# Altium schematic sheet Extra5_connectors.SchDoc
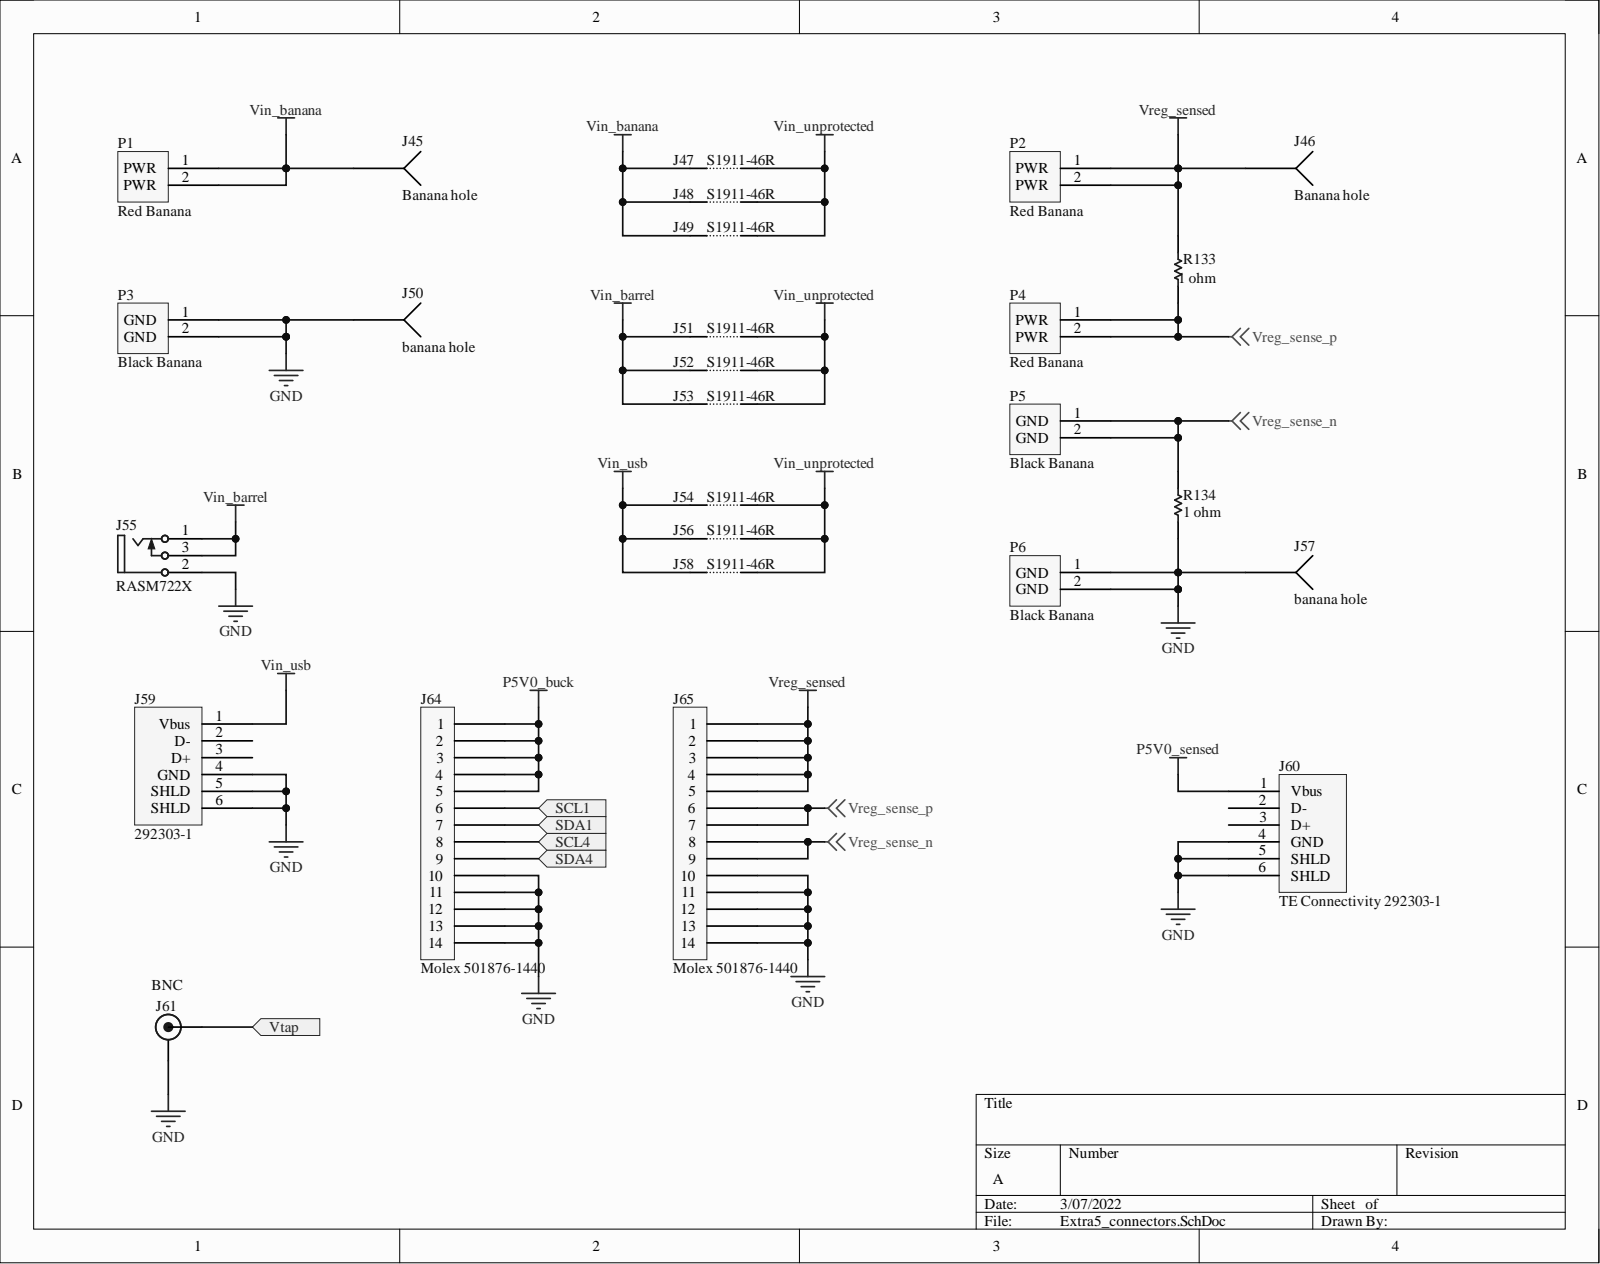
[diagram p0: Extra5_connectors.SchDoc]

## Parts
J45  Banana hole  [PCB-0iteas2z8ibrtv14j3fn-1]
J46  Banana hole  [PCB-0iteas2z8ibrtv14j3fn-1]
J47  S1911-46R  [PCB-ohesuu85b1wonmmrbvbk-1]
J48  S1911-46R  [PCB-ohesuu85b1wonmmrbvbk-1]
J49  S1911-46R  [PCB-ohesuu85b1wonmmrbvbk-1]
J50  banana hole  [PCB-0iteas2z8ibrtv14j3fn-1]
J51  S1911-46R  [PCB-ohesuu85b1wonmmrbvbk-1]
J52  S1911-46R  [PCB-ohesuu85b1wonmmrbvbk-1]
J53  S1911-46R  [PCB-ohesuu85b1wonmmrbvbk-1]
J54  S1911-46R  [PCB-ohesuu85b1wonmmrbvbk-1]
J55  RASM722X  [PCB-3wyqglupejej19j9tyc1-1]
J56  S1911-46R  [PCB-ohesuu85b1wonmmrbvbk-1]
J57  banana hole  [PCB-0iteas2z8ibrtv14j3fn-1]
J58  S1911-46R  [PCB-ohesuu85b1wonmmrbvbk-1]
J59  292303-1  [PCB-13antodwz0m2yw6c6qha-1]
J60  TE Connectivity 292303-1  [PCB-13antodwz0m2yw6c6qha-1]
J61  BNC  [PCB-o9wtl2yy4dlkql9rizqo-1]
J64  Molex 501876-1440  Manufacturer Part Number: 501876-1440  [PCB-1usx3krib1t3yl72r4im-1]
J65  Molex 501876-1440  Manufacturer Part Number: 501876-1440  [PCB-1usx3krib1t3yl72r4im-1]
P1  Red Banana  Color: Red  [PCB-upaa7zt6pz2ck3tbpqlf-1]
P2  Red Banana  Color: Red  [PCB-upaa7zt6pz2ck3tbpqlf-1]
P3  Black Banana  Color: Black  [PCB-adkavfswxprd42xd6ct2-1]
P4  Red Banana  Color: Red  [PCB-upaa7zt6pz2ck3tbpqlf-1]
P5  Black Banana  Color: Black  [PCB-adkavfswxprd42xd6ct2-1]
P6  Black Banana  Color: Black  [PCB-adkavfswxprd42xd6ct2-1]
R133  1 ohm  [PCB-0002-00362-1]
R134  1 ohm  [PCB-0002-00362-1]

## Ports
SCL1, SCL4, SDA1, SDA4, Vtap

## Nets
GND: J50.1 J55.2 J57.1 J59.4(GND) J59.5(SHLD) J59.6(SHLD) J60.4(GND) J60.5(SHLD) J60.6(SHLD) J61.2 J64.10 J64.11 J64.12 J64.13 J64.14 J65.10 J65.11 J65.12 J65.13 J65.14 P3.1 P3.2 P6.1 P6.2 R134.1
P5V0_buck: J64.1 J64.2 J64.3 J64.4 J64.5
P5V0_sensed: J60.1(Vbus)
Vin_banana: J45.1 J47.1 J48.1 J49.1 P1.1 P1.2
Vin_barrel: J51.1 J52.1 J53.1 J55.1 J55.3
Vin_unprotected: J47.2 J48.2 J49.2 J51.2 J52.2 J53.2 J54.2 J56.2 J58.2
Vin_usb: J54.1 J56.1 J58.1 J59.1(Vbus)
Vreg_sense_n: J65.8 J65.9 P5.1 P5.2 R134.2
Vreg_sense_p: J65.6 J65.7 P4.1 P4.2 R133.1
Vreg_sensed: J46.1 J65.1 J65.2 J65.3 J65.4 J65.5 P2.1 P2.2 R133.2
SCL1: J64.6 ; port SCL1
SCL4: J64.8 ; port SCL4
SDA1: J64.7 ; port SDA1
SDA4: J64.9 ; port SDA4
Vtap: J61.1 ; port Vtap

Not connected: J59 2(D-) 3(D+); J60 2(D-) 3(D+)

## Library data of the parts
J45 J46 J50 J57 = CMP-a2bb6bb1a39b7d7c-1: BONDHUS 15816 Hex L-Wrench - Short 1/2In. More details: 1/2In Hex L-wrench - Short, Standard Tool (Accessory Needed f...; Manufacturer Part Number: 1581-6; Current Rating: 15.0 A; Gender: Male; Lead-Free Status: Lead Free; Number of Positions: 1; Voltage Rating (DC): 2.50 kV; Color: Blue; Component Kind: Standard; Contact Material: Brass; Contact Plating: Tin; Insulation Material: Polycarbonate; Library Name: My Personal Space; Mounting Style: Panel; Octopart URL: http://octopart.com/1581-6-pomona+electronics-9286; OctopartID: a2bb6bb1a39b7d7c; Packaging: Bulk; RoHS: Compliant; Size-Diameter: 4.22 mm; Size-Length: 31.0 mm
J47-J49 J51-J54 J56 J58 = CMP-1660de3de5e9c9a7-6: Conn Jumper 2 POS 5.38mm Solder ST SMD T/R; Number of Contacts: 2; Component Kind: Standard; Library Name: My Personal Space; Manufacturer Part Number: S1911-46R; Octopart URL: https://octopart.com/s1911-46r-harwin-22533871; OctopartID: 1660de3de5e9c9a7; Packaging: Cut Tape (CT); REACH SVHC Compliance: No SVHC; RoHS: Compliant
J55 = CMP-330658-7: Connector Power PL 2 POS Solder RA SMD 3 Terminal 1 Port Bulk; Current Rating: 5.00 A; Gender: Female; Lead-Free Status: Lead Free; Number of Contacts: 2; Voltage Rating (AC): 250 V; Color: Black; Component Kind: Standard; Library Name: My Personal Space; Manufacturer Part Number: RASM722X; Mounting Style: Surface Mount, Solder, Through Hole, PCB; Octopart URL: https://octopart.com/rasm722x-switchcraft-142066; OctopartID: 976c5e6498f848ab; REACH SVHC Compliance: No SVHC; RoHS: Compliant
J59 J60 = CMP-777690-15: Conn USB 2.0 Type A RCP 4 POS 2mm/2.5mm Solder RA Thru-Hole 4 Terminal 1 Port Box/Tube; Contact Resistance: 10.0 mΩ (max); Current Rating: 1.00 A; ELV Compliant: Compliant; Gender: Female; Insulation Resistance: 1.00 GΩ (min); Lead-Free Status: Lead Free; Number of Contacts: 4; Number of Mating Cycles: 1500; Voltage Rating (AC): 30.0 V; Component Kind: Standard; Contact Material: Copper Alloy; Contact Plating: Gold Flash over Nickel, Gold Flash, Gold, Gold over Nickel; Flammability Rating: UL 94 V-0; Housing Color: Black; Housing Material: Thermoplastic; Library Name: My Personal Space; Lifecycle Status: Active; Manufacturer Part Number: 292303-1; Mounting Style: Through Hole, Solder, PCB; Number of Positions: 4; Octopart URL: https://octopart.com/292303-1-te+connectivity-46505331; OctopartID: 7da7b668c5b2a260; Operating Temperature: -55.0 °C to 85.0 °C; Orientation: Right Angle; Packaging: Tube, Box; REACH SVHC Compliance: No SVHC; RoHS: Compliant; Size-Height: 5.75 mm; Size-Length: 14.0 mm; Size-Width: 13.1 mm
J61 = CMP-a9d46285cb1c7613-1: Right Angle 50Ohms PCB Mount BNC Connector, jack, Solder Termination Nickel; Manufacturer Part Number: 5414373-1; ELV Compliant: Compliant; Gender: Male; Lead-Free Status: Lead Free; Component Kind: Standard; Contact Material: Phosphor Bronze; Contact Plating: Gold; Library Name: My Personal Space; Lifecycle Status: Active; Mounting Style: Through Hole, PCB; Octopart URL: http://octopart.com/5414373-1-te+connectivity+%2F+amp-39536558; OctopartID: a9d46285cb1c7613; Orientation: Right Angle; Packaging: Tray; REACH SVHC Compliance: No SVHC; RoHS: Compliant
J64 J65 = CMP-d98e10ef34c60649-1: 2.00mm (.079"") Pitch iGrid? Wire-to-Board Header, Dual Row, Right Angle, 14 Circuits; Current Rating: 2.00 A; Gender: Male; Glow Wire Compliant: Non-Compliant; Number of Circuits: 12, 14; Component Kind: Standard; Contact Material: Brass; Contact Plating: Tin; Halogen Free Status: Not Low Halogen; Library Name: My Personal Space; Lifecycle Status: Active; Mounting Style: Through Hole; Number of Rows: 2; Octopart URL: https://octopart.com/501876-1440-molex-7063598; OctopartID: d98e10ef34c60649; Orientation: Right Angle; REACH SVHC Compliance: No SVHC; RoHS: Compliant
P1 P2 P4 = CMP-31dcfbd9f7fdee1b-1: Jack; Red; 5 A; Nylon; Copper Aluminum Alloy; 0.052 in. (Mounting Hole); Manufacturer Part Number: 105-1102-001; Current Rating: 5.00 A; Gender: Female; Lead-Free Status: Lead Free; Voltage Rating (DC): 2.10 kV; Component Kind: Standard; Contact Plating: Silver; Insulation Material: Nylon; Library Name: My Personal Space; Mounting Style: PCB, Horizontal, Solder; Octopart URL: http://octopart.com/105-1102-001-cinch+connectors-51372871; OctopartID: 31dcfbd9f7fdee1b; Orientation: Right Angle; Packaging: Bulk; RoHS: Compliant; Size-Diameter: 2.00 mm; Size-Inner Diameter: 2.00 mm
P3 P5 P6 = CMP-eccf26ca2ae13308-1: Jack; Black; 5 A; Nylon; Copper Aluminum Alloy; 0.052 in. (Mounting Hole); Manufacturer Part Number: 105-1103-001; Current Rating: 5.00 A; Gender: Female; Lead-Free Status: Lead Free; Voltage Rating (DC): 2.10 kV; Component Kind: Standard; Contact Plating: Silver; Insulation Material: Nylon; Library Name: My Personal Space; Mounting Style: PCB, Horizontal, Solder; Octopart URL: http://octopart.com/105-1103-001-cinch+connectors-51372872; OctopartID: eccf26ca2ae13308; Orientation: Right Angle; Packaging: Bulk; REACH SVHC Compliance: No SVHC; RoHS: Compliant; Size-Diameter: 2.00 mm; Size-Inner Diameter: 2.00 mm
R133 R134 = CMP-7eb9b7135b62a17e-2: ResThinFilm 0805 1R 0.25% 125mW TC25; Manufacturer Part Number: CRT0805-CY-1R00ELF; Component Kind: Standard; Library Name: My Personal Space; Octopart URL: http://octopart.com/crt0805-cy-1r00elf-bourns-10485949; OctopartID: 7eb9b7135b62a17e; RoHS: Compliant
